# Altium schematic sheet SCH_YX-H181_D71276_V04_240813.SchDoc
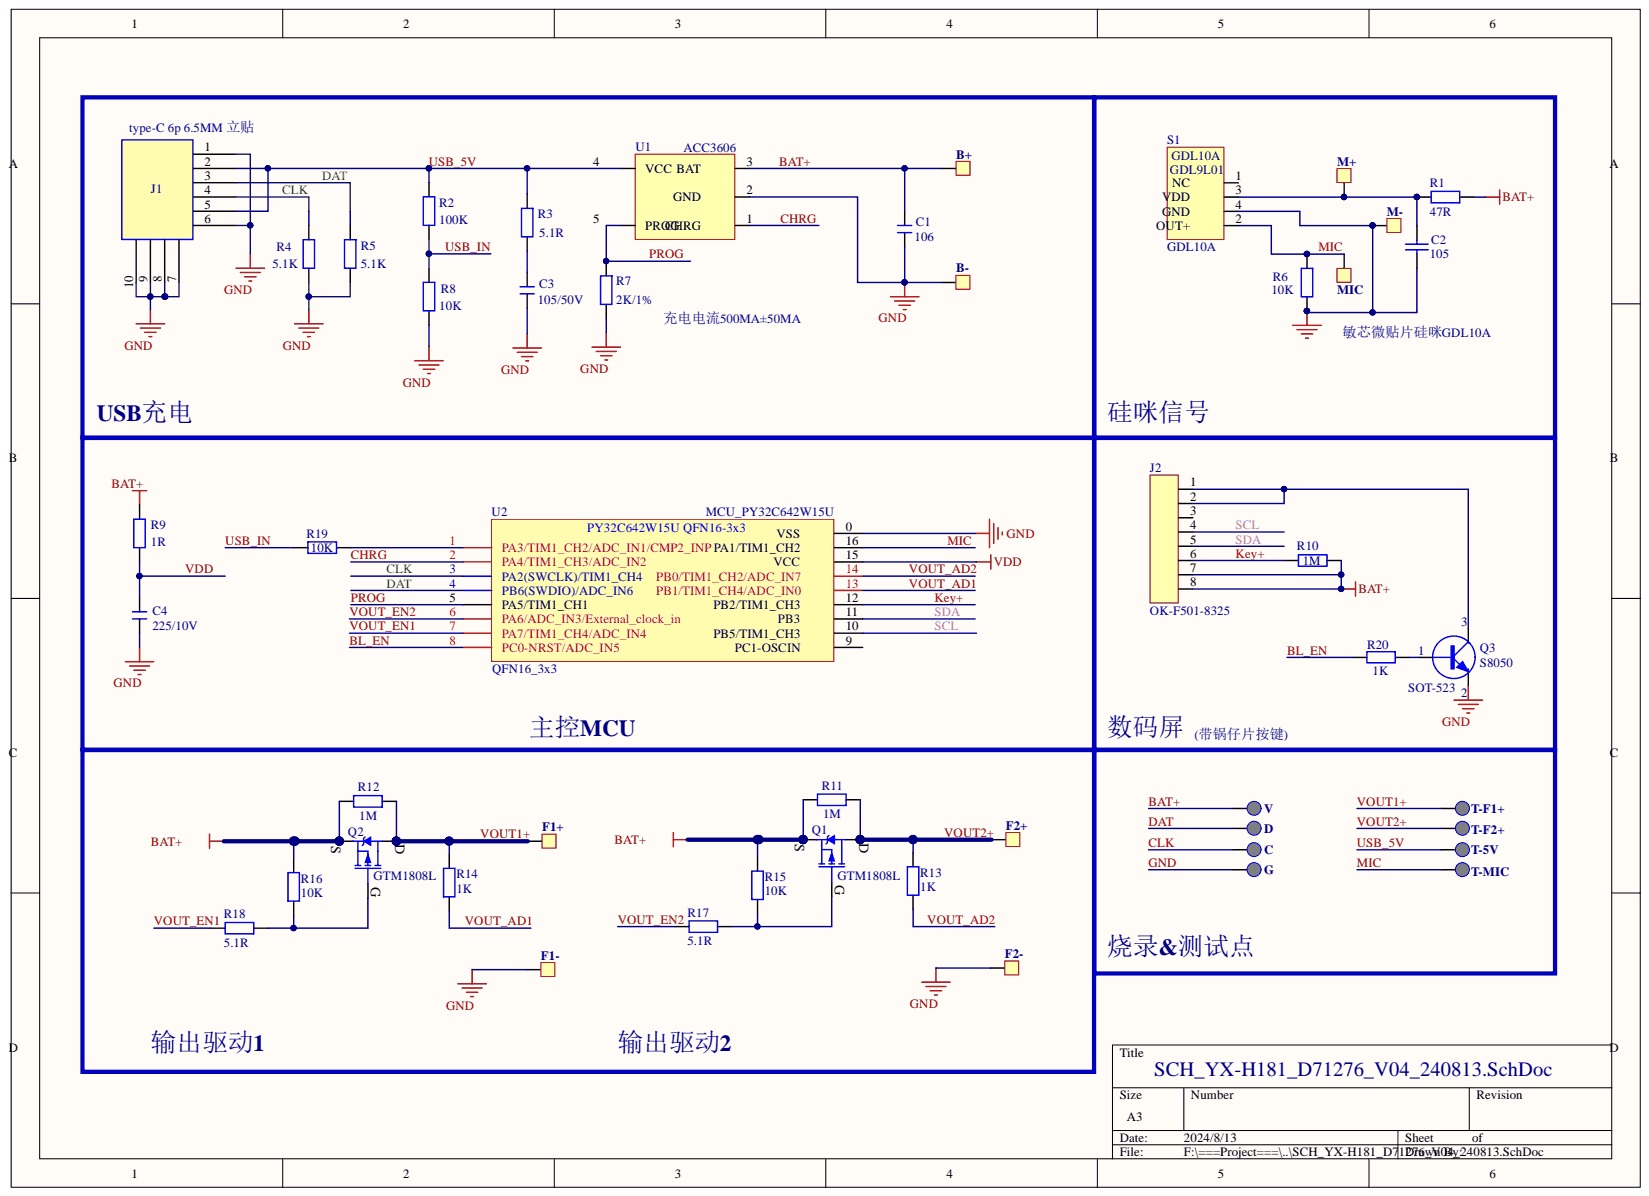
[diagram p0: SCH_YX-H181_D71276_V04_240813.SchDoc]

## Parts
B+  (no value)  [TEST_PAD_1.2]  (no BOM)
B-  (no value)  [TEST_PAD_1.2]  (no BOM)
C  (no value)  [TEST_TP_1.0]  (no BOM)
C1  106  [C0402]
C2  105  [C0402]
C3  105/50V  [C0402]
C4  225/10V  [C0402]
D  (no value)  [TEST_TP_1.0]  (no BOM)
F1+  (no value)  [TEST_PAD_1.2]  (no BOM)
F1-  (no value)  [TEST_PAD_1.2]  (no BOM)
F2+  (no value)  [TEST_PAD_1.2]  (no BOM)
F2-  (no value)  [TEST_PAD_1.2]  (no BOM)
G  (no value)  [TEST_TP_1.0]  (no BOM)
J1  type-C 6p 6.5MM 立贴  [USB_TYPE-C_6P_180D_SMD_Model]
J2  OK-F501-8325  [FPC_0.5_8P_翻盖]
M+  (no value)  [TEST_PAD_1.2]  (no BOM)
M-  (no value)  [TEST_PAD_1.2]  (no BOM)
MIC  (no value)  [TEST_PAD_1.2]  (no BOM)
Q1  GTM1808L  [SOT-23]
Q2  GTM1808L  [SOT-23]
Q3  S8050  [SOT-523]
R1  47R  [R0402]
R2  100K  [R0402]
R3  5.1R  [R0402]
R4  5.1K  [R0402]
R5  5.1K  [R0402]
R6  10K  [R0402]
R7  2K/1%  [R0402]
R8  10K  [R0402]
R9  1R  [R0603]
R10  1M  [R0402]
R11  1M  [R0402]
R12  1M  [R0402]
R13  1K  [R0402]
R14  1K  [R0402]
R15  10K  [R0402]
R16  10K  [R0402]
R17  5.1R  [R0402]
R18  5.1R  [R0402]
R19  10K  [R0402]
R20  1K  [R0402]
S1  GDL10A  [MIC_MSPC01-GDL10A]
T-5V  (no value)  [TEST_TP_1.0]  (no BOM)
T-F1+  (no value)  [TEST_TP_1.0]  (no BOM)
T-F2+  (no value)  [TEST_TP_1.0]  (no BOM)
T-MIC  (no value)  [TEST_TP_1.0]  (no BOM)
U1  ACC3606  [SOT-23-5]
U2  MCU_PY32C642W15U  [QFN16_3x3]
V  (no value)  [TEST_TP_1.0]  (no BOM)

## Nets
BAT+: B+.1 C1.2 J2.7 J2.8 Q1.2 Q2.2 R1.2 R9.2 R10.1 R11.1 R12.1 R15.1 R16.1 U1.3 V.1
BL_EN: R20.2 U2.8(PC0-NRST/ADC_IN5)
CHRG: U1.1 U2.2(PA4/TIM1_CH3/ADC_IN2)
CLK: C.1 J1.4 R4.2 U2.3(PA2(SWCLK)/TIM1_CH4)
DAT: D.1 J1.3 R5.2 U2.4(PB6(SWDIO)/ADC_IN6)
GND: B-.1 C1.1 C2.1 C3.1 C4.2 F1-.1 F2-.1 G.1 J1.1 J1.6 J1.7 J1.8 J1.9 J1.10 M-.1 Q3.2 R4.1 R5.1 R6.1 R7.1 R8.1 S1.4 U1.2 U2.0
KEY+: J2.6 R10.2 U2.12
MIC: MIC.1 R6.2 S1.2 T-MIC.1 U2.16
PROG: R7.2 U1.5(PROG) U2.5(PA5/TIM1_CH1)
SCL: J2.4 U2.10
SDA: J2.5 U2.11
USB_5V: J1.2 J1.5 R2.2 R3.2 T-5V.1 U1.4(VCC)
USB_IN: R2.1 R8.2 R19.2
VDD: C4.1 R9.1 U2.15
VOUT1+: F1+.1 Q2.3 R12.2 R14.1 T-F1+.1
VOUT2+: F2+.1 Q1.3 R11.2 R13.1 T-F2+.1
VOUT_AD1: R14.2 U2.13
VOUT_AD2: R13.2 U2.14
VOUT_EN1: R18.1 U2.7(PA7/TIM1_CH4/ADC_IN4)
VOUT_EN2: R17.1 U2.6(PA6/ADC_IN3/External_clock_in)
NetC2_2: C2.2 M+.1 R1.1 S1.3
NetC3_2: C3.2 R3.1
NetJ2_1: J2.1 J2.2 Q3.3
NetQ1_1: Q1.1 R15.2 R17.2
NetQ2_1: Q2.1 R16.2 R18.2
NetQ3_1: Q3.1 R20.1
NetR19_1: R19.1 U2.1

Not connected: J2 3; S1 1; U2 9

## Library data of the parts
B+ B- F1+ F1- F2+ F2- M+ M- MIC = TP-SM: Test Point, Surface Mount, Circular, Pad Diameter 1 mm; Library Name: ETM-IC.SchLib
C D G T-5V T-F1+ T-F2+ T-MIC V = TP-1: Test Point, Surface Mount, Circular, Pad Diameter 1 mm; Library Name: SCH_FootPrint.SchLib
C1 C3 C4 = CAP: 贴片电容; BOM名称: 无极性贴片电容; 版权归属: 源创客; Component Kind: Standard; 学习资源: www.ooocke.com; Library Name: YXWSCHLIB.SchLib
C2 = 0603 100nF (104) 10% 50V: 贴片电容; Component Kind: Standard; ComponentLink1Description: 供应商链接; ComponentLink1URL: http://www.szlcsc.com/product/details_15331.html; Library Name: Miscellaneous Devices LC.IntLib; Package: 0603; Supplier: LC; SuppliersPartNumber: C14663
J1 = TYPE_C_6P: Component Kind: Standard; PCB Footprint: TYPE_C_6P
J2 = CON_8: Component Kind: Standard; Library Name: SCH_FootPrint.SchLib
Q1 Q2 = 2301: PMOS; BOM名称: P沟道场效应管; 版权归属: 源创客; Component Kind: Standard; 学习资源: www.ooocke.com; Library Name: YXWSCHLIB.SchLib
Q3 = BJT_NPN: NPN 三极管; Component Kind: Standard; Library Name: SCH_FootPrint.SchLib
R1 = R: 贴片电阻; 版权归属: 源创客; BOM名称: 贴片电阻; Component Kind: Standard; 学习资源: www.ooocke.com; Library Name: Copy of YX-W149-V8 V1_0.SCHLIB
R2-R19 = RES: 贴片电阻; 版权归属: 源创客; BOM名称: 贴片电阻; Component Kind: Standard; 学习资源: www.ooocke.com; Library Name: YXWSCHLIB.SchLib
R20 = 电阻: Component Kind: Standard; Library Name: 原理图库.SchLib
S1 = MIC_贴片硅咪_1: Component Kind: Standard; Library Name: SCH_FootPrint.SchLib
U1 = LP4068: 充电管理; Component Kind: Standard; Library Name: YXWSCHLIB.SchLib
U2 = MCU_PY32C642W15U: Component Kind: Standard; Library Name: SCH_FootPrint.SchLib
